# Altium schematic sheet Driver.SchDoc
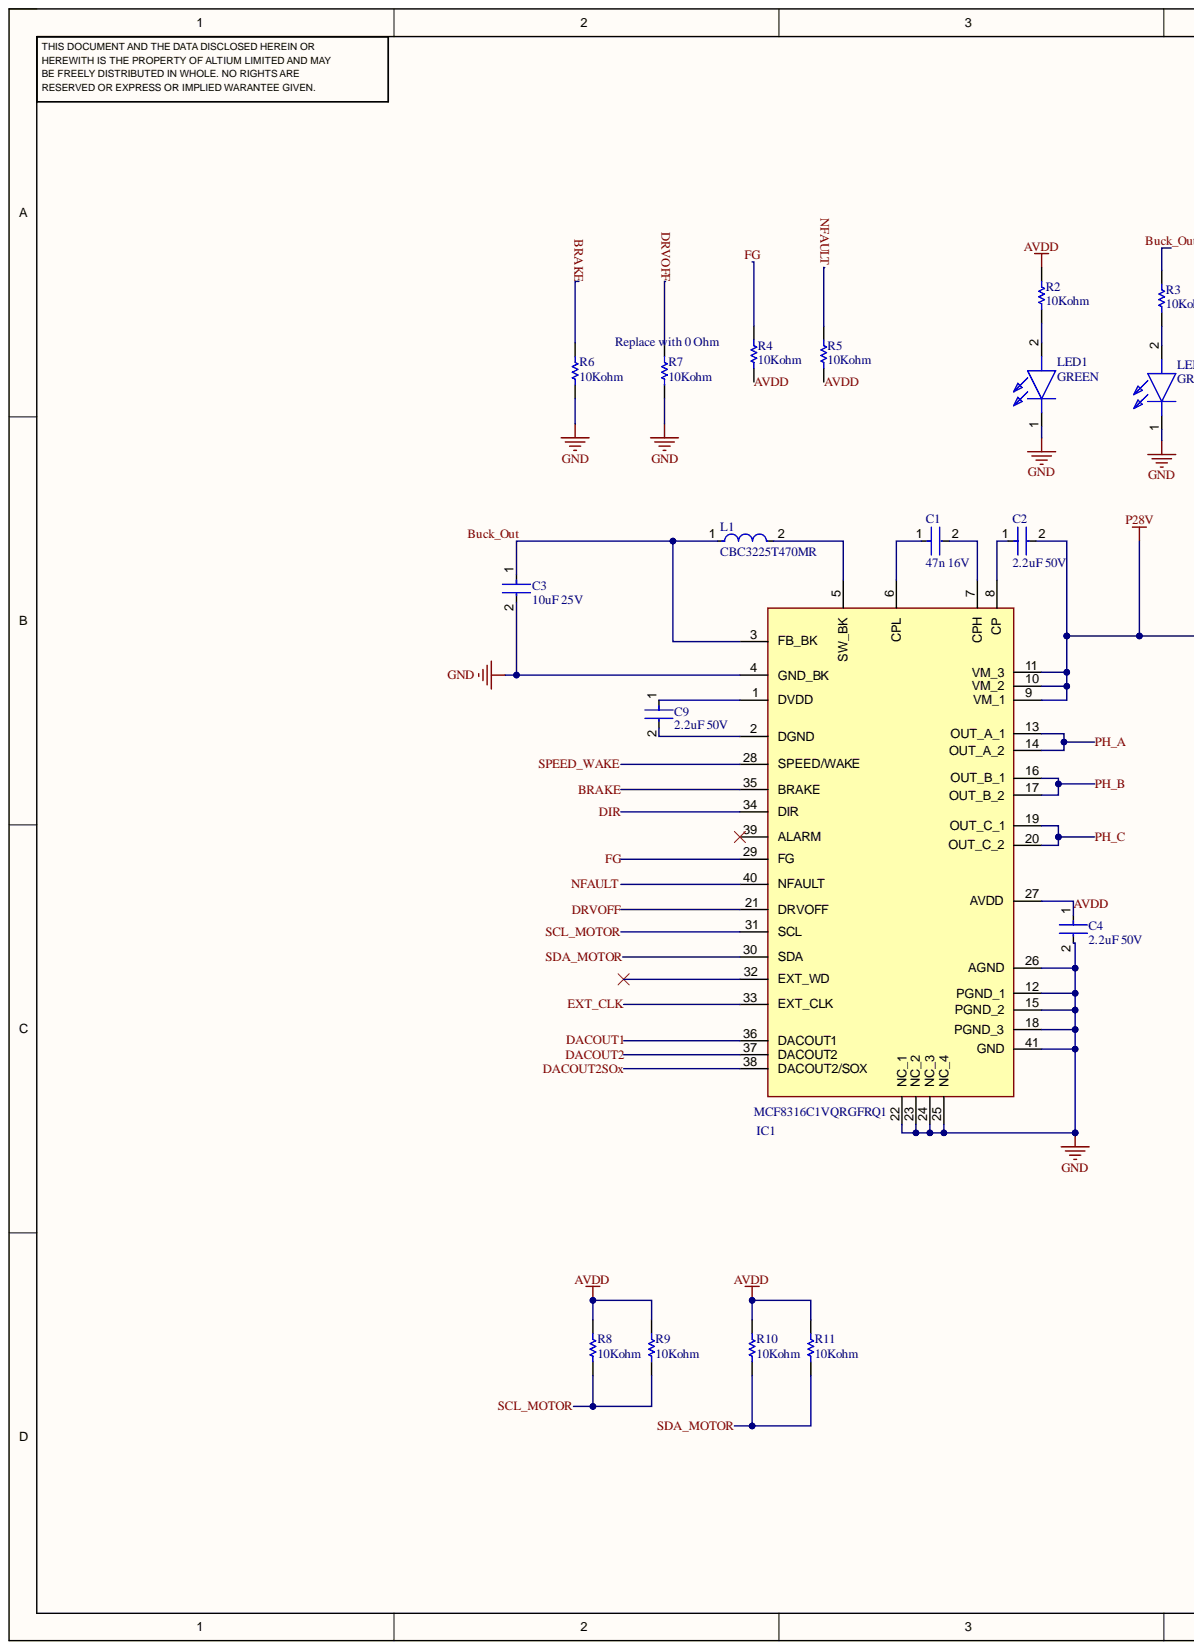
[diagram p0: Driver.SchDoc, left]
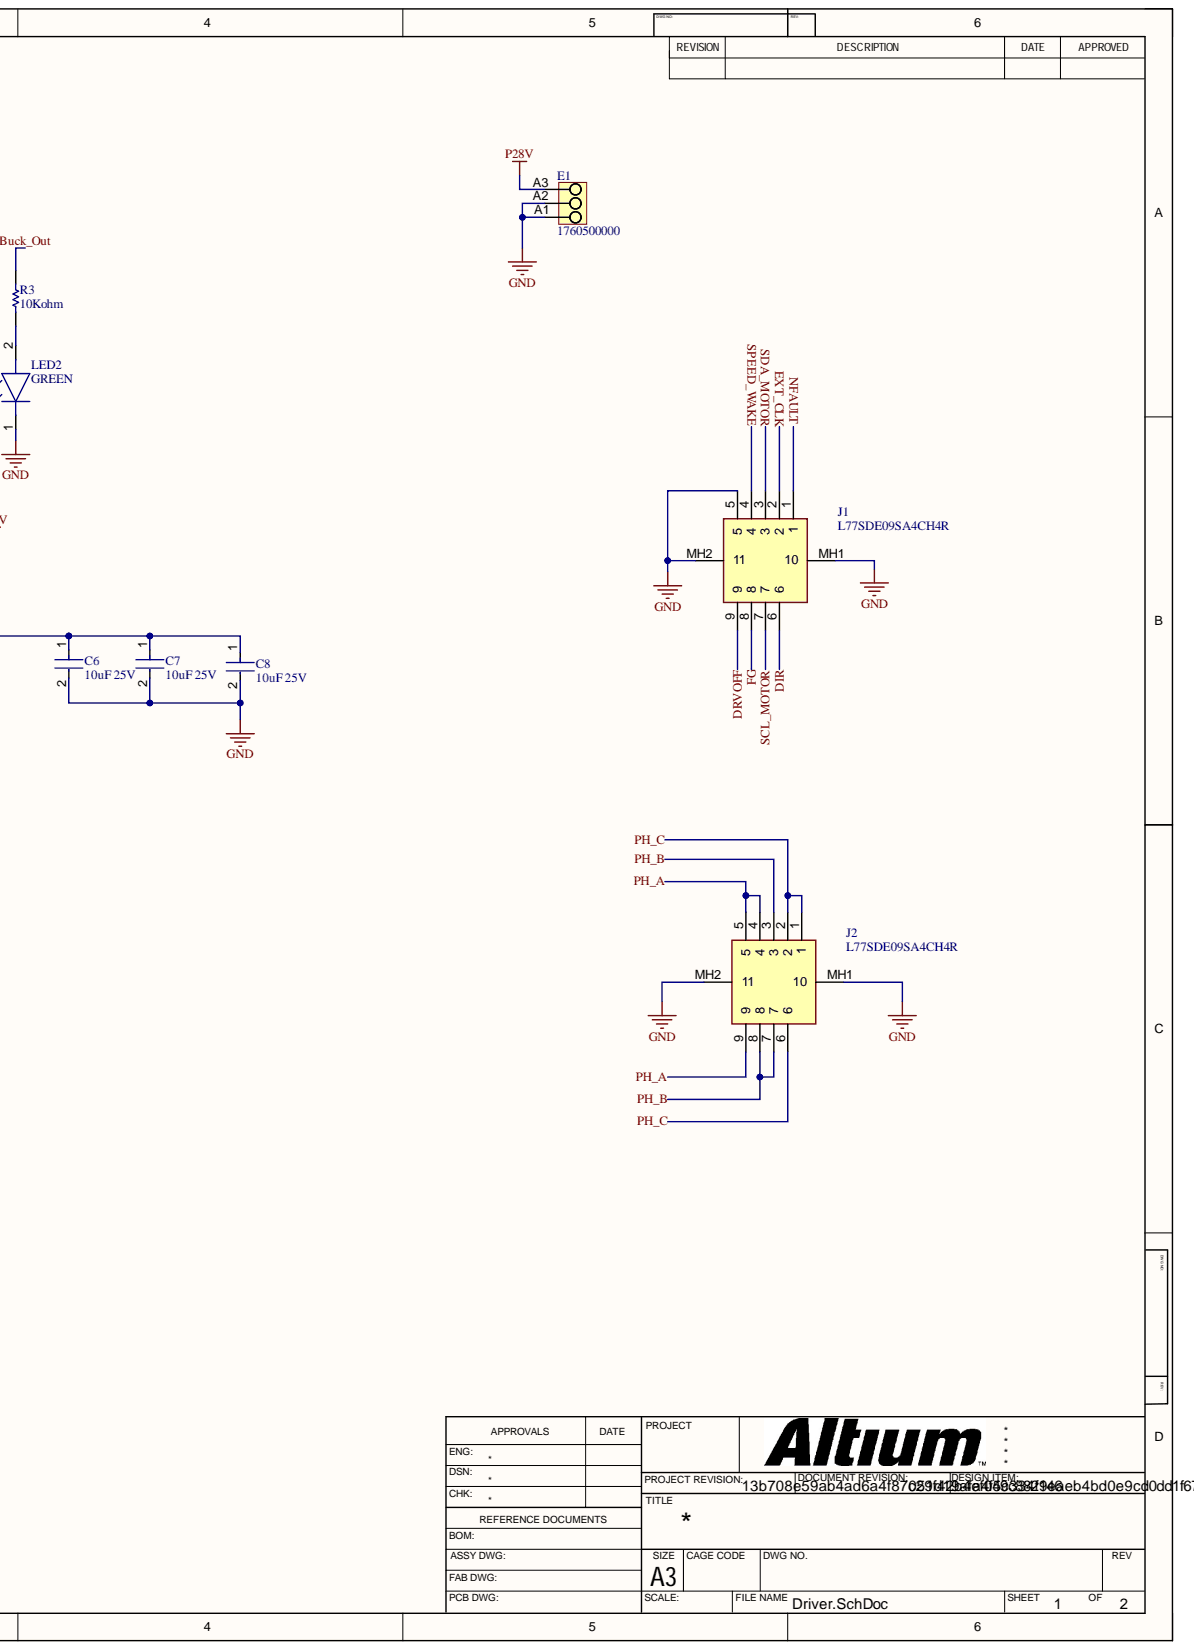
[diagram p1: Driver.SchDoc, right]

## Parts
C1  47n 16V  Voltage: 16V  [FP-0603-L_1_6_0_15-W_0_81-IPC_A]
C2  2.2uF 50V  Capacitance: 2.2uF  Voltage Rating: 50V  [FP-C2012-125-0_2-IPC_C]
C3  10uF 25V  Capacitance: 10uF  Voltage Rating: 25V  [FP-CL10-IPC_C]
C4  2.2uF 50V  Capacitance: 2.2uF  Voltage Rating: 50V  [FP-C2012-125-0_2-IPC_C]
C6  10uF 25V  Capacitance: 10uF  [FP-CL10-IPC_C]
C7  10uF 25V  Capacitance: 10uF  Voltage Rating: 25V  [FP-CL10-IPC_C]
C8  10uF 25V  Capacitance: 10uF  Voltage Rating: 25V  [FP-CL10-IPC_C]
C9  2.2uF 50V  Capacitance: 2.2uF  [FP-C2012-125-0_2-IPC_C]
E1  1760500000  [PM_5_08_90_03]
IC1  MCF8316C1VQRGFRQ1  [QFN50P500X700X100-41N-D]
J1  L77SDE09SA4CH4R  [L77SDE09SA4CH4R]
J2  L77SDE09SA4CH4R  [L77SDE09SA4CH4R]
L1  CBC3225T470MR  [FP-CB3225-IPC_A]
LED1  GREEN  [VLMTG1400GS08]
LED2  GREEN  [VLMTG1400GS08]
R2  10Kohm  [RESC2013X60X35NL10T20]
R3  10Kohm  [RESC2013X60X35NL10T20]
R4  10Kohm  [RESC2013X60X35NL10T20]
R5  10Kohm  [RESC2013X60X35NL10T20]
R6  10Kohm  [RESC2013X60X35NL10T20]
R7  10Kohm  [RESC2013X60X35NL10T20]
R8  10Kohm  [RESC2013X60X35NL10T20]
R9  10Kohm  [RESC2013X60X35NL10T20]
R10  10Kohm  [RESC2013X60X35NL10T20]
R11  10Kohm  [RESC2013X60X35NL10T20]

## Nets
GND: C3.2 C4.2 C6.2 C7.2 C8.2 E1.A1 E1.A2 IC1.4(GND_BK) IC1.12(PGND_1) IC1.15(PGND_2) IC1.18(PGND_3) IC1.22(NC_1) IC1.23(NC_2) IC1.24(NC_3) IC1.25(NC_4) IC1.26(AGND) IC1.41(GND) J1.5 J1.MH1 J1.MH2 J2.MH1 J2.MH2 LED1.1 LED2.1 R6.1 R7.1
P28V: C2.2 C6.1 C7.1 C8.1 E1.A3 IC1.9(VM_1) IC1.10(VM_2) IC1.11(VM_3)
AVDD: C4.1 IC1.27(AVDD) R2.2 R4.1 R5.1 R8.2 R9.2 R10.2 R11.2
BRAKE: IC1.35(BRAKE) R6.2
Buck_Out: C3.1 IC1.3(FB_BK) L1.1 R3.2
DACOUT1: IC1.36(DACOUT1)
DACOUT2: IC1.37(DACOUT2)
DACOUT2SOx: IC1.38(DACOUT2/SOX)
DIR: IC1.34(DIR) J1.6
DRVOFF: IC1.21(DRVOFF) J1.9 R7.2
EXT_CLK: IC1.33(EXT_CLK) J1.2
FG: IC1.29(FG) J1.8 R4.2
NFAULT: IC1.40(NFAULT) J1.1 R5.2
PH_A: IC1.13(OUT_A_1) IC1.14(OUT_A_2) J2.4 J2.5 J2.9
PH_B: IC1.16(OUT_B_1) IC1.17(OUT_B_2) J2.3 J2.7 J2.8
PH_C: IC1.19(OUT_C_1) IC1.20(OUT_C_2) J2.1 J2.2 J2.6
SCL_MOTOR: IC1.31(SCL) J1.7 R8.1 R9.1
SDA_MOTOR: IC1.30(SDA) J1.3 R10.1 R11.1
SPEED_WAKE: IC1.28(SPEED/WAKE) J1.4
NetC1_1: C1.1 IC1.6(CPL)
NetC1_2: C1.2 IC1.7(CPH)
NetC2_1: C2.1 IC1.8(CP)
NetC9_1: C9.1 IC1.1(DVDD)
NetC9_2: C9.2 IC1.2(DGND)
NetIC1_5: IC1.5(SW_BK) L1.2
NetLED1_2: LED1.2 R2.1
NetLED2_2: LED2.2 R3.1

Not connected: IC1 32(EXT_WD) 39(ALARM)

## Library data of the parts
C1 = CMP-2006-04519-2: General Purpose Ceramic Capacitor, 0603, 47nF, 10%, X7R, 15%, 16V  0603YC473KAT2A; Capacitance: 47nF; Case Code (Imperial): 0603; Case Code (Metric): 1608; Case/Package: 0603; Component Kind: Standard; Construction: Flat; Contact Plating: Tin; Depth: 0.81mm; Dielectric: X7R; Height: 0.9mm; Lead Free: Lead Free; Length: 1.6mm; Library Name: Altium Content Vault; Max Operating Temperature: 125°C; Min Operating Temperature: -55°C; Mount: Surface Mount; Number of Pins: 2; Package Quantity: 1; Packaging: Tape and Reel; Radiation Hardening: No; REACH SVHC: No SVHC; RoHS Compliant: Yes; Series: -; Termination: SMD/SMT; Thickness: 0.035inch; Tolerance: 10%; Voltage Rating: 16V; Voltage Rating (DC): 16V; Width: 0.032inch
C2 C4 C9 = CMP-08246-005698-1: Multilayer Ceramic Capacitors 2.2μF ±10% 50V X5R SMD 0805  C2012X5R1H225K125AB; Dissipation Factor: 5%; Insulation Resistance: 10GR; Case Code (Imperial): 0805; Case Code (Metric): 2012; Case/Package: 0805; Component Kind: Standard; Construction: Flat; Depth: 1.25mm; Dielectric: X5R; Dielectric Material: Ceramic; Height: 1.25mm; Lead Free: Lead Free; Length: 2mm; Max Operating Temperature: 85°C; Min Operating Temperature: -55°C; Mount: Surface Mount; Package Quantity: 1; Packaging: Tape and Reel; Radiation Hardening: No; REACH SVHC: No SVHC; Resistor Type: Ceramic; RoHS Compliant: Yes; Series: C; Termination: SMD/SMT; Thickness: 0.057inch; Tolerance: 10%; Voltage Rating (DC): 50V; Weight: 0.000194oz; Width: 1.2mm; Library Name: AMB_Demo_Driver.SCHLIB
  C9: Voltage Rating: 50V
C3 C6-C8 = CMP-13271-004224-1: Cap Ceramic 10uF 25V X5R ±20% Pad SMD 0603 +85°C T/R  CL10A106MA8NRNC; Case Code (Imperial): 0603; Case Code (Metric): 1608; Case/Package: 0603; Component Kind: Standard; Contact Plating: Tin; Dielectric: X5R; Lead Free: Lead Free; Length: 0.063inch; Max Operating Temperature: 85°C; Min Operating Temperature: -55°C; Mount: Surface Mount; Package Quantity: 1; Packaging: Tape and Reel; Termination: Wraparound; Thickness: 0.035inch; Tolerance: 20%; Width: 0.031inch; Library Name: AMB_Demo_Driver.SCHLIB
  C6: Voltage Rating: 25V
E1 = CMP-1559-01629-1: OMNIMATE Signal - series PM; Manufacturer: Weidmueller; Contact_material: Cu-Leg; Contact_surface: tinned; Insulating_material: Wemid (PA); Mounting_onto_the_PCB: Solder connection; No_of_poles: 3; Pitch_inch: 0,2 inch; Pitch_mm: 5,08 mm; Rated_current_use_group_B_UL_1059: 15 A; Rated_voltage_for_surge_voltage_class_pollution_degree_II_2: 600 V; Rated_voltage_use_group_B_UL_1059: 300 V; Short_text_for_material: PM 5.08/03/90 3.5SN OR BX; Side_termination_characteristic: closed side; Solder_pin_length_mm: 3,5 mm; Solid_max_H05_07_V_U: 2,5 mm²; Solid_min_H05_07_V_U: 0,13 mm²; UL_94_flammability_rating: V-0; Wire_cross_section_AWG_max_UL_1059: AWG 14; Wire_cross_section_AWG_min_UL_1059: AWG 26; Colour: Orange; Component Kind: Standard; ComponentLink1Description: Manufacturer URL; ComponentLink1URL: http://www.weidmueller.com/; ComponentLink2Description: URL Link1; ComponentLink2URL: http://catalog.weidmueller.com/catalog/Start.do?localeId=en&ObjectID=1760500000; Library Name: Altium Content Vault; PackageReference: PM_5_08_90_03; Packaging: Box; PartNumber: 1760500000; Qty: 500 PCS; Revision: V1
IC1 = MCF8316C1VQRGFRQ1: TI 3 Phase BLDC PMSM Motor driver with integated MOSFETs, supporting FOC and offline control; Manufacturer_Name: Texas Instruments; Component Kind: Standard; Datasheet Link: https://www.ti.com/general/docs/suppproductinfo.tsp?distId=26&gotoUrl=https://www.ti.com/lit/gpn/mcf8316c-q1; Height: 1mm; Manufacturer_Part_Number: MCF8316C1VQRGFRQ1; Mouser Part Number: 595-CF8316C1VQRGFRQ1; Mouser Price/Stock: https://www.mouser.co.uk/ProductDetail/Texas-Instruments/MCF8316C1VQRGFRQ1?qs=HoCaDK9Nz5d%2F2nxGM%252B%2FPww%3D%3D; Library Name: AMB_Demo_Driver.SCHLIB
J1 J2 = L77SDE09SA4CH4R: Dsub 9 position female 5A 2 row; Manufacturer_Name: Amphenol Communications Solutions; Arrow Part Number: L77SDE09SA4CH4R; Arrow Price/Stock: https://www.arrow.com/en/products/l77sde09sa4ch4r/amphenol-fci?utm_currency=USD&region=nac; Component Kind: Standard; Datasheet Link: https://cdn.amphenol-cs.com/media/wysiwyg/files/drawing/l77sdxxxsa4ch4r.pdf; Height: 12.85mm; Library Name: FOC_MCU_LIB.SchLib; Manufacturer_Part_Number: L77SDE09SA4CH4R; Mouser Part Number: 523-L77SDE09SA4CH4R; Mouser Price/Stock: https://www.mouser.co.uk/ProductDetail/Amphenol-Commercial-Products/L77SDE09SA4CH4R?qs=mq7kV%2Fq8lk7IPBi8IaqHjw%3D%3D
L1 = CMP-14484-000045-1: SMD Inductors 47uH ±20% 0.48A 0.871Ω 1210; Core Material: Ferrite; Current Rating: 480mA; DC Resistance (DCR): 871mR; Inductor Type: POWER; Resistance: 0.871R; Saturation Current: 390mA; Self Resonant Frequency: 10MHz; Shielding: Unshielded; Test Frequency: 100kHz; Case Code (Imperial): 1210; Case Code (Metric): 3225; Case/Package: 1210; Component Kind: Standard; DC Current: 480mA; Depth: 2.7mm; Halogen Free: Halogen Free; Height: 2.5mm; Height - Seated (Max): 0.106inch; Inductance: 47uH; Inductance Tolerance: 20%; Lead Free: Lead Free; Length: 3.4mm; Library Name: Altium Content Vault; Max DC Current: 480mA; Max Operating Temperature: 105°C; Military Standard: Not; Min Operating Temperature: -40°C; Mount: Surface Mount; Number of Pins: 2; Package Quantity: 1000; Packaging: Tape and Reel; Radiation Hardening: No; Resistor Type: Wirewound; RoHS Compliant: Yes; Series: CB; Termination: SMD/SMT; Tolerance: 20%; Width: 0.098inch
LED1 LED2 = VLMTG1400-GS08: LED Green  VLMTG1400-GS08  Vf=2.6V @10mA  Imax 20mA; Manufacturer_Name: Vishay; Arrow Part Number: VLMTG1400-GS08; Arrow Price/Stock: https://www.arrow.com/en/products/vlmtg1400-gs08/vishay; Component Kind: Standard; Datasheet Link: https://www.vishay.com/docs/84393/vlmtg1400.pdf; Height: 0.65mm; Manufacturer_Part_Number: VLMTG1400-GS08; Mouser Part Number: 78-VLMTG1400-GS08; Mouser Price/Stock: https://www.mouser.co.uk/ProductDetail/Vishay-Semiconductors/VLMTG1400-GS08?qs=gt1LBUVyoHlZ6yHd8iEIzQ%3D%3D
R2-R11 = CMP-1659-00013-4: Chip Resistor, 10 KOhm, +/- 1%, 0.125 W, -55 to 155 degC, 0805 (2012 Metric), RoHS, Tape and Reel  RC0805FR-0710KL; Manufacturer: Yageo; Voltage Rating: 150 V; Component Kind: Standard; ComponentLink1Description: Manufacturer URL; ComponentLink1URL: http://www.yageo.com/; ComponentLink2Description: Datasheet; ComponentLink2URL: http://www.yageo.com/documents/recent/PYu-RC_Group_51_RoHS_L_04.pdf; DatasheetVersion: Rev. 4, 02/2016; Height: 0.5 mm; Length: 2 mm; Library Name: Altium Content Vault; Max Operating Temperature: 155 degC; Min Operating Temperature: -55 degC; Mount: Surface Mount; Mounting Technology: Surface Mount; Package Quantity: 5000; PackageDescription: 2-Pin Surface Mounted Device, Body 2 x 1.25 mm; PackageReference: 0805; Packaging: Tape and Reel; Pins: 2; REACH SVHC: No SVHC; RoHSCompliant: true
